# Altium schematic sheet 07 Audio.SchDoc
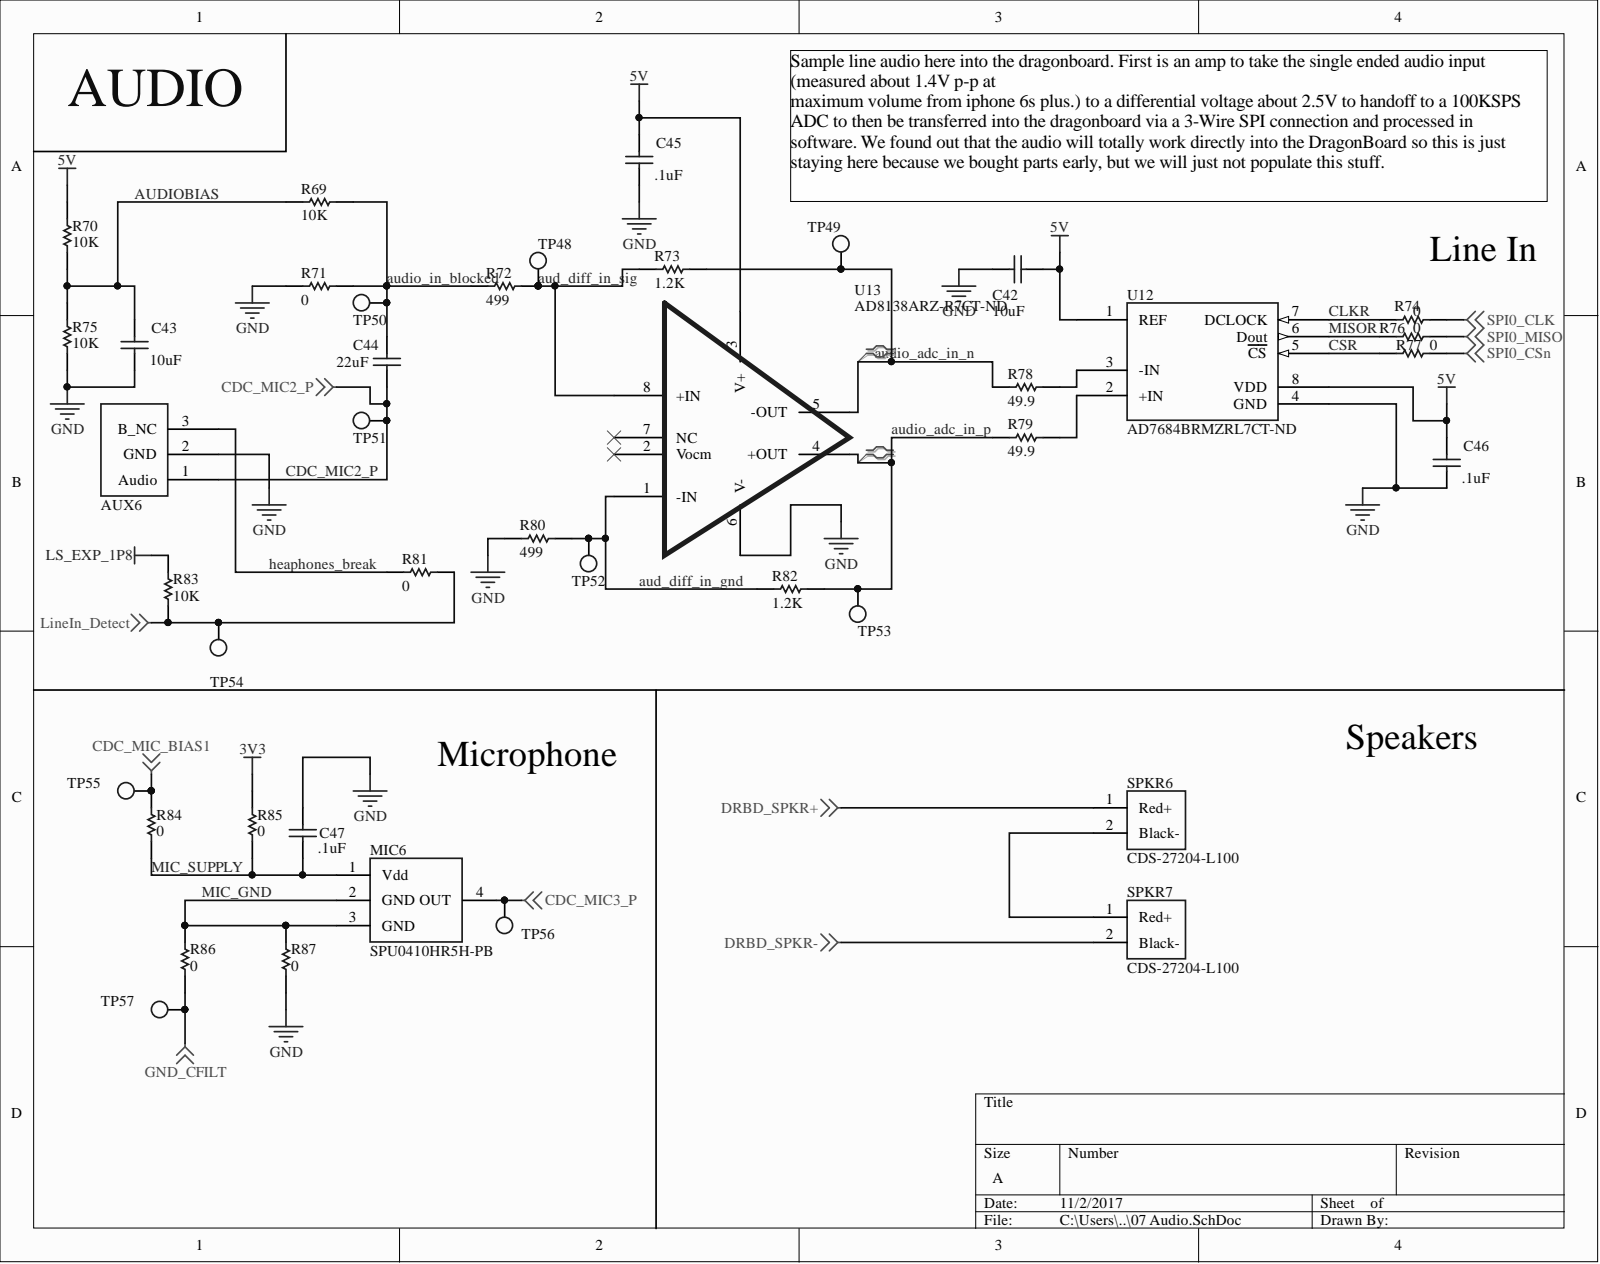
[diagram p0: 07 Audio.SchDoc]

## Parts
AUX6  CP-3523MJCT-ND  [Audio_Conn_Mono]  (value not drawn)
C42  value 10uF  Capacitance: 10uF  [CAPC2012X135N]  (hidden comment: CAP_0805_10u_16V)
C43  value 10uF  Capacitance: 10uF  [CAPC2012X135N]  (hidden comment: CAP_0805_10u_16V)
C44  value 22uF  Capacitance: 22uF  [CAPC2012X135N]  (hidden comment: CAP_0805_22u_25V_BLOCK)
C45  value .1uF  [CAPC2012X135N]  (hidden comment: CAP_0805_.1u_250V)
C46  value .1uF  [CAPC2012X135N]  (hidden comment: CAP_0805_.1u_250V)
C47  value .1uF  [CAPC2012X135N]  (hidden comment: CAP_0805_.1u_250V)
MIC6  SPU0410HR5H-PB  [MIC_FP]
R69  Resistance: 10K  [RES0805]  (hidden comment: RES_0805_10K)
R70  Resistance: 10K  [RES0805]  (hidden comment: RES_0805_10K)
R71  RES_0805_JMP  [RES0805]  (value not drawn)
R72  RES_0805_499  [RES0805]  (value not drawn)
R73  Resistance: 1.2K  [RES0805]  (hidden comment: RES_0805_1.2K)
R74  RES_0805_JMP  [RES0805]  (value not drawn)
R75  Resistance: 10K  [RES0805]  (hidden comment: RES_0805_10K)
R76  RES_0805_JMP  [RES0805]  (value not drawn)
R77  RES_0805_JMP  [RES0805]  (value not drawn)
R78  Resistance: 49.9  [RES0805]  (hidden comment: RES_0805_49.9)
R79  Resistance: 49.9  [RES0805]  (hidden comment: RES_0805_49.9)
R80  RES_0805_499  [RES0805]  (value not drawn)
R81  RES_0805_JMP  [RES0805]  (value not drawn)
R82  Resistance: 1.2K  [RES0805]  (hidden comment: RES_0805_1.2K)
R83  Resistance: 10K  [RES0805]  (hidden comment: RES_0805_10K)
R84  RES_0805_JMP  [RES0805]  (value not drawn)
R85  RES_0805_JMP  [RES0805]  (value not drawn)
R86  RES_0805_JMP  [RES0805]  (value not drawn)
R87  RES_0805_JMP  [RES0805]  (value not drawn)
SPKR6  CDS-27204-L100  [PHOENIX_2POS_1935161]
SPKR7  CDS-27204-L100  [PHOENIX_2POS_1935161]
TP48  TP  [TestPoint_0805]
TP49  TP  [TestPoint_0805]
TP50  TP  [TestPoint_0805]
TP51  TP  [TestPoint_0805]
TP52  TP  [TestPoint_0805]
TP53  TP  [TestPoint_0805]
TP54  TP  [TestPoint_0805]
TP55  TP  [TestPoint_0805]
TP56  TP  [TestPoint_0805]
TP57  TP  [TestPoint_0805]
U12  AD7684BRMZRL7CT-ND  [MSOP8]
U13  AD8138ARZ-R7CT-ND  [SOIC127P600X175-8N]

## Nets
3V3: R85.2
5V: C42.1 C45.2 C46.2 R70.2 U12.1(REF) U12.8(VDD) U13.3(V+)
CDC_MIC3_P: MIC6.4(OUT) TP56.1
CDC_MIC_BIAS1: R84.2 TP55.1
DRBD_SPKR+: SPKR6.1
DRBD_SPKR-: SPKR7.2
GND: AUX6.2 C42.2 C43.1 C45.1 C46.1 C47.2 R71.1 R75.1 R80.1 R87.1 U12.4(GND) U13.6(V-)
GND_CFILT: R86.1 TP57.1
LineIn_Detect: R81.2 R83.1 TP54.1
LS_EXP_1P8: R83.2
SPI0_CLK: R74.2
SPI0_CSn: R77.2
SPI0_MISO: R76.2
aud_diff_in_gnd: R80.2 R82.1 TP52.1 U13.1(-IN)
aud_diff_in_sig: R72.2 R73.1 TP48.1 U13.8(+IN)
audio_adc_in_n: R73.2 R78.1 TP49.1 U13.5(-OUT)
audio_adc_in_p: R79.1 R82.2 TP53.1 U13.4(+OUT)
audio_in_blocked: C44.2 R69.2 R71.2 R72.1 TP50.1
AUDIOBIAS: C43.2 R69.1 R70.1 R75.2
CDC_MIC2_P: AUX6.1 C44.1 TP51.1
CLKR: R74.1 U12.7(DCLOCK)
CSR: R77.1 U12.5(CS)
heaphones_break: AUX6.3 R81.1
MIC_GND: MIC6.2(GND) MIC6.3(GND) R86.2 R87.2
MIC_SUPPLY: C47.1 MIC6.1(Vdd) R84.1 R85.1
MISOR: R76.1 U12.6(Dout)
NetR78_2: R78.2 U12.3(-IN)
NetR79_2: R79.2 U12.2(+IN)
NetSPKR6_2: SPKR6.2 SPKR7.1

Not connected: U13 2(Vocm) 7(NC)

## Library data of the parts
AUX6 = CP-3523MJCT-ND: 3.50mm (0.141", 1/8", Mini Plug) - Headphone Phone Jack Mono Connector Solder; Manufacturer: CUI; Manufacturer Part Number: MJ-3523-SMT-TR; Current Rating: 1A; Gender: Female; Shielding: Unshielded; Case/Package: SMD/SMT; Component Kind: Standard; Contact Plating: Silver; Lead Free: Lead Free; Packaging: Cut Tape; Pricing 1: 1=1.02, 10=0.9, 25=0.8476, 50=0.8122, 100=0.7768, 250=0.7062 (USD); Stock 1: 44959; Supplier 1: Digi-Key; Supplier Part Number 1: CP-3523MJCT-ND; Termination: Solder; Library Name: schlib_truman.SchLib
C42 C43 = CAP_0805_10u_16V: Cap Ceramic 10uF 16V X5R 10% SMD 0805 85C T/R; Manufacturer: Johanson Dielectrics; Manufacturer Part Number: 160R15X106KV4E; Case/Package: 0805; Case Code (Imperial): 0805; Case Code (Metric): 2012; Component Kind: Standard; ComponentLink1Description: https://datasheet.ciiva.com/26948/getdatasheetpartid-132904-26948555.pdf; ComponentLink1URL: https://datasheet.ciiva.com/26948/getdatasheetpartid-132904-26948555.pdf; Depth: 1.27mm; Dielectric: X5R; Height: 1.27mm; Length: 2.03mm; Max Operating Temperature: 85°C; Min Operating Temperature: -55°C; Mount: Surface Mount; Number of Pins: 2; Package Quantity: 2000; Packaging: Tape and Reel; Pricing 1: 1=0.55, 10=0.387, 100=0.2552, 500=0.189, 1000=0.1607 (USD); Radiation Hardening: No; REACH SVHC: No SVHC; Stock 1: 36636; Supplier 1: Digi-Key; Supplier Part Number 1: 709-1229-1-ND; Termination: SMD/SMT; Thickness: 0.06inch; Tolerance: 10%; Voltage Rating: 16V; Voltage Rating (DC): 16V; Weight: 0.000194oz; Width: 1.27mm; Library Name: schlib_truman.SchLib
C44 = CAP_0805_22u_25V_BLOCK: 22µF ±20% 25V X5R Ceramic Capacitor -55°C ~ 85°C Surface Mount, MLCC 0805 (2012 Metric) 0.079" L x 0.049" W (2.00mm x 1.25mm); Manufacturer: Samsung; Manufacturer Part Number: CL21A226MAQNNNE; Case/Package: 0805; Case Code (Imperial): 0805; Case Code (Metric): 2012; Component Kind: Standard; ComponentLink1Description: https://datasheet.ciiva.com/25724/cl21a226maqnnne-25724468.pdf; ComponentLink1URL: https://datasheet.ciiva.com/25724/cl21a226maqnnne-25724468.pdf; Contact Plating: Nickel,Tin; Depth: 1.25mm; Dielectric: X5R; Length: 2mm; Max Operating Temperature: 85°C; Min Operating Temperature: -55°C; Mount: Surface Mount; Package Quantity: 1; Packaging: Tape and Reel; Pricing 1: 1=0.92, 10=0.678, 100=0.465, 500=0.3681, 1000=0.31 (USD); Stock 1: 536403; Supplier 1: Digi-Key; Supplier Part Number 1: 1276-2908-1-ND; Termination: Wraparound; Thickness: 0.055inch; Tolerance: 20%; Voltage Rating: 25V; Width: 0.049inch; Library Name: schlib_truman.SchLib
C45-C47 = CAP_0805_.1u_250V: Cap Ceramic 0.1uF 250V X7T 10% SMD 0805 125C Plastic T/R; Manufacturer: TDK; Manufacturer Part Number: C2012X7T2E104K125AE; Capacitance: 100nF; Case/Package: 0805; Case Code (Imperial): 0805; Case Code (Metric): 2012; Component Kind: Standard; ComponentLink1Description: https://datasheet.ciiva.com/24219/c2012x7t2e104k125ae-265154-24219519.pdf; ComponentLink1URL: https://datasheet.ciiva.com/24219/c2012x7t2e104k125ae-265154-24219519.pdf; Composition: Ceramic; Depth: 1.25mm; Dielectric: X7T; Height: 1.25mm; Length: 2mm; Max Operating Temperature: 125°C; Min Operating Temperature: -55°C; Package Quantity: 1; Packaging: Tape and Reel; Pricing 1: 1=0.37, 10=0.25, 100=0.1419, 500=0.1002, 1000=0.0877 (USD); REACH SVHC: No SVHC; Stock 1: 30376; Supplier 1: Digi-Key; Supplier Part Number 1: 445-8883-1-ND; Termination: SMD/SMT; Thickness: 0.049inch; Tolerance: 10%; Voltage Rating: 250V; Voltage Rating (DC): 250V; Weight: 0.000194oz; Width: 0.05inch; Library Name: schlib_truman.SchLib
MIC6 = SPU0410HR5H-PB: MIC MEMS ANALOG OMNI -42DB; Manufacturer: Knowles; Direction: Omnidirectional; Impedance: 300R; Operating Supply Current: 250uA; Shape: Rectangular; Signal to Noise Ratio (SNR): 59dB; Voltage Rating: 3.6V; Component Kind: Standard; ComponentLink1Description: https://datasheet.ciiva.com/5300/spu0410hr5h-58393-5300133.pdf; ComponentLink1URL: https://datasheet.ciiva.com/5300/spu0410hr5h-58393-5300133.pdf; ComponentLink2Description: https://datasheet.ciiva.com/1/spu0410hr5h-pb-1958.pdf; ComponentLink2URL: https://datasheet.ciiva.com/1/spu0410hr5h-pb-1958.pdf; ComponentLink3Description: https://datasheet.ciiva.com/1/spu0410hr5h-pb-1959.pdf; ComponentLink3URL: https://datasheet.ciiva.com/1/spu0410hr5h-pb-1959.pdf; Depth: 1.2mm; Height: 1.2mm; Length: 3.76mm; Manufacturer Part Number: SPU0410HR5H-PB; Package Quantity: 1200; Packaging: Tape and Reel; Pricing 1: 1=0.94, 10=0.806, 25=0.6476, 50=0.5756, 100=0.5036, 250=0.4892, 500=0.4748 (USD); Stock 1: 176050; Supplier 1: Digi-Key; Supplier Part Number 1: 423-1138-1-ND; Termination: SMD/SMT; Width: 2.95mm; Library Name: schlib_truman.SchLib
R69 R70 R75 R83 = RES_0805_10K: RES, THICK FILM, 10K, 5%, 0.125W, 0805; Manufacturer: Yageo; Manufacturer Part Number: RC0805JR-0710KL; Power Rating: 125mW; Temperature Coefficient: 100ppm/°C; Voltage Rating: 150V; Case/Package: 0805; Case Code (Imperial): 0805; Case Code (Metric): 2012; Component Kind: Standard; ComponentLink1Description: https://datasheet.ciiva.com/7983/444164-7983765.pdf; ComponentLink1URL: https://datasheet.ciiva.com/7983/444164-7983765.pdf; ComponentLink2Description: https://datasheet.ciiva.com/7941/444164-7941870.pdf; ComponentLink2URL: https://datasheet.ciiva.com/7941/444164-7941870.pdf; ComponentLink3Description: https://datasheet.ciiva.com/7941/444164-7941871.pdf; ComponentLink3URL: https://datasheet.ciiva.com/7941/444164-7941871.pdf; Composition: Thick Film; Contact Plating: Tin,Matte; Depth: 1.25mm; Height: 0.5mm; Lead Free: Lead Free; Length: 2mm; Max Operating Temperature: 155°C; Military Standard: Not; Min Operating Temperature: -55°C; Packaging: Tape and Reel; Pricing 1: 1=0.1, 10=0.019, 100=0.0076, 1000=0.0034, 2500=0.003 (USD); Radiation Hardening: No; REACH SVHC: Unknown; Stock 1: 1285290; Supplier 1: Digi-Key; Supplier Part Number 1: 311-10KARCT-ND; Termination: SMD/SMT; Tolerance: 5%; Width: 1.25mm; Library Name: schlib_truman.SchLib
R71 R74 R76 R77 R81 R84-R87 = RES_0805_JMP: RES, THICK FILM, 0R, 0.125W, 0805; Manufacturer: Yageo; Manufacturer Part Number: RC0805JR-070RL; Power Rating: 125mW; Resistance: 0mR; Temperature Coefficient: 200ppm/°C; Tolerance: 5%; Voltage Rating: 150V; : 0; Case/Package: 0805; Case Code (Imperial): 0805; Case Code (Metric): 2012; Component Kind: Standard; ComponentLink1Description: https://datasheet.ciiva.com/7941/1738694-7941861.pdf; ComponentLink1URL: https://datasheet.ciiva.com/7941/1738694-7941861.pdf; ComponentLink2Description: https://datasheet.ciiva.com/7941/1738694-7941862.pdf; ComponentLink2URL: https://datasheet.ciiva.com/7941/1738694-7941862.pdf; ComponentLink3Description: https://datasheet.ciiva.com/11819/0900766b8106beab-11819430.pdf; ComponentLink3URL: https://datasheet.ciiva.com/11819/0900766b8106beab-11819430.pdf; ComponentLink4Description: https://datasheet.ciiva.com/7941/1738694-7941863.pdf; ComponentLink4URL: https://datasheet.ciiva.com/7941/1738694-7941863.pdf; ComponentLink5Description: https://datasheet.ciiva.com/15190/getdatasheetpartid-330685-15190028.pdf; ComponentLink5URL: https://datasheet.ciiva.com/15190/getdatasheetpartid-330685-15190028.pdf; Composition: Thick Film; Contact Plating: Tin,Matte; Depth: 1.25mm; Height: 0.5mm; Lead Free: Lead Free; Length: 2mm; Max Operating Temperature: 155°C; Military Standard: Not; Min Operating Temperature: -55°C; Number of Pins: 2; Packaging: Tape and Reel; Pricing 1: 1=0.1, 10=0.018, 25=0.0128, 50=0.0096, 100=0.0071, 250=0.0054, 500=0.0044, 1000=0.0032 (USD); Radiation Hardening: No; REACH SVHC: Unknown; Stock 1: 6469744; Supplier 1: Digi-Key; Supplier Part Number 1: 311-0.0ARCT-ND; Termination: SMD/SMT; Voltage Rating (DC): 150V; Width: 1.25mm; Library Name: schlib_truman.SchLib
R72 R80 = RES_0805_499: Res Thick Film 0805 499 Ohm 1% 0.125W(1/8W) ±100ppm/C Molded SMD Automotive T/R; Manufacturer: Stackpole Electronics; Manufacturer Part Number: RMCF0805FT499R; Max Power Dissipation: 125mW; Resistance: 499; Temperature Coefficient: 100ppm/°C; Case/Package: 0805; Case Code (Imperial): 0805; Case Code (Metric): 2012; Component Kind: Standard; ComponentLink1Description: https://www.verical.com/server-webapp/html/getDatasheet?partID=363156; ComponentLink1URL: https://www.verical.com/server-webapp/html/getDatasheet?partID=363156; ComponentLink2Description: http://components.arrow.com/part/dataview/44988387S7171819N1174; ComponentLink2URL: http://components.arrow.com/part/dataview/44988387S7171819N1174; ComponentLink3Description: https://www.seielect.com/catalog/SEI-RMCF_RMCP.pdf; ComponentLink3URL: https://www.seielect.com/catalog/SEI-RMCF_RMCP.pdf; ComponentLink4Description: http://www.seielect.com/Catalog/SEI-RMC.pdf; ComponentLink4URL: http://www.seielect.com/Catalog/SEI-RMC.pdf; Composition: Thick Film; Contact Plating: Tin,Matte; Depth: 1.25mm; Height: 0.026inch; Lead Free: Lead Free; Length: 2mm; Max Operating Temperature: 155°C; Military Standard: Not; Min Operating Temperature: -55°C; Mount: Surface Mount; Number of Terminations: 2; Packaging: Tape and Reel; Power Rating: 125mW; Pricing 1: 1=0.1, 10=0.023, 100=0.0095, 1000=0.0043, 2500=0.0037 (USD); Radiation Hardening: No; Stock 1: 258760; Supplier 1: Digi-Key; Supplier Part Number 1: RMCF0805FT499RCT-ND; Tolerance: 1%; Width: 0.049inch; Library Name: schlib_truman.SchLib
R73 R82 = RES_0805_1.2K: Res Thick Film 0805 1.2K Ohm 5% 0.125W(1/8W) ±200ppm/C Molded SMD Automotive T/R; Manufacturer: Stackpole Electronics; Manufacturer Part Number: RMCF0805JT1K20; Max Power Dissipation: 125mW; Temperature Coefficient: 200ppm/°C; Case/Package: 0805; Case Code (Imperial): 0805; Case Code (Metric): 2012; Component Kind: Standard; ComponentLink1Description: https://www.verical.com/server-webapp/html/getDatasheet?partID=408272; ComponentLink1URL: https://www.verical.com/server-webapp/html/getDatasheet?partID=408272; ComponentLink2Description: http://components.arrow.com/part/dataview/50565311S7077410N1174; ComponentLink2URL: http://components.arrow.com/part/dataview/50565311S7077410N1174; ComponentLink3Description: https://www.seielect.com/catalog/SEI-RMCF_RMCP.pdf; ComponentLink3URL: https://www.seielect.com/catalog/SEI-RMCF_RMCP.pdf; Composition: Thick Film; Contact Plating: Tin,Matte; Depth: 1.25mm; Height: 0.026inch; Lead Free: Lead Free; Length: 0.079inch; Max Operating Temperature: 155°C; Military Standard: Not; Min Operating Temperature: -55°C; Mount: Surface Mount; Number of Terminations: 2; Packaging: Tape and Reel; Pricing 1: 1=0.1, 10=0.017, 100=0.0067, 1000=0.003, 2500=0.0026 (USD); Radiation Hardening: No; Stock 1: 133190; Supplier 1: Digi-Key; Supplier Part Number 1: RMCF0805JT1K20CT-ND; Tolerance: 5%; Width: 0.049inch; Library Name: schlib_truman.SchLib
R78 R79 = RES_0805_49.9: RES SMD 49.9 OHM 1% 1/8W 0805; Manufacturer: Yageo; Manufacturer Part Number: RC0805FR-0749R9L; Max Power Dissipation: 125mW; Temperature Coefficient: 100ppm/°C; Voltage Rating: 150V; Case/Package: 0805; Case Code (Imperial): 0805; Case Code (Metric): 2012; Component Kind: Standard; ComponentLink1Description: https://datasheet.ciiva.com/11808/0900766b8106beab-11808260.pdf; ComponentLink1URL: https://datasheet.ciiva.com/11808/0900766b8106beab-11808260.pdf; ComponentLink2Description: https://datasheet.ciiva.com/12667/rc0805-12667333.pdf; ComponentLink2URL: https://datasheet.ciiva.com/12667/rc0805-12667333.pdf; ComponentLink3Description: https://datasheet.ciiva.com/17041/rc0805-17041420.pdf; ComponentLink3URL: https://datasheet.ciiva.com/17041/rc0805-17041420.pdf; Composition: Thick Film; Depth: 1.25mm; Height: 0.024inch; Lead Free: Lead Free; Length: 2mm; Max Operating Temperature: 155°C; Military Standard: Not; Min Operating Temperature: -55°C; Mount: Surface Mount; Number of Terminations: 2; Package Quantity: 1; Packaging: Tape and Reel; Power Rating: 125mW; Pricing 1: 1=0.1, 10=0.022, 100=0.0088, 1000=0.004, 2500=0.0034 (USD); Stock 1: 531225; Supplier 1: Digi-Key; Supplier Part Number 1: 311-49.9CRCT-ND; Termination: SMD/SMT; Tolerance: 1%; Voltage Rating (DC): 150V; Width: 0.049inch; Library Name: schlib_truman.SchLib
SPKR6 SPKR7 = CDS-27204-L100: 4 Ohm Magnetic Speaker 2W 20Hz ~ 20kHz Top Rectangular 93dB; Manufacturer: CUI; Approvals: UL; Connector Type: Screw; Current: 18A; Current Rating: 16A; Impedance: 4R; Max Power Dissipation: 2.5W; Max Supply Voltage: 250V; Number of Circuits: 2; Number of Levels: 1; Pitch: 5mm; Voltage: 300V; Voltage Rating (DC): 320V; Wire/Cable Gauge: 14AWG; Wire Gauge (Max): 26AWG; Wire Gauge (Min): 12AWG; Colour: Green; Component Kind: Standard; ComponentLink1Description: https://datasheet.ciiva.com/824/combiconcompact5-0mmseries-824222.pdf; ComponentLink1URL: https://datasheet.ciiva.com/824/combiconcompact5-0mmseries-824222.pdf; ComponentLink2Description: https://datasheet.ciiva.com/15169/getdatasheetpartid-177903-15169663.pdf; ComponentLink2URL: https://datasheet.ciiva.com/15169/getdatasheetpartid-177903-15169663.pdf; ComponentLink3Description: https://datasheet.ciiva.com/16047/1935161-16047247.pdf; ComponentLink3URL: https://datasheet.ciiva.com/16047/1935161-16047247.pdf; Depth: 9mm; Flammability Rating: UL94 V-0; Height: 6.1mm; Housing Colour: Green; Housing Material: Polyamide; Insulation Material: Nylon; Lead Free: Lead Free; Length: 27mm; Manufacturer Part Number: CDS-27204-L100; Material: Nylon; Max Current Rating: 16A; Mount: Through Hole; Number of Contacts: 2; Number of Poles: 2; Number of Positions: 2; Number of Positions Per Level: 2; Number of Rows: 1; Orientation: Straight; Package Quantity: 250; Packaging: Bulk; Power Rating: 2W; Pricing 1: 1=3.55, 10=3.179, 25=2.8052, 50=2.5246, 100=2.4871, 250=2.3842, 500=2.2908, 1000=2.244 (USD); Pricing 2: 1=0.38, 10=0.368, 50=0.352, 100=0.3424, 250=0.32, 500=0.2944, 1000=0.272 (USD); Radiation Hardening: No; Shape: Rectangular; Stock 1: 445; Stock 2: 16172; Supplier 1: Digi-Key; Supplier 2: Digi-Key; Supplier Part Number 1: 102-3547-ND; Supplier Part Number 2: 277-1667-ND; Termination: Wire Leads; Voltage Rating: 250V; Weight: 0.067021oz; Width: 20mm; Library Name: schlib_truman.SchLib
TP48-TP57 = TP: Testpoint_0805; Manufacturer: TE Connectivity; Manufacturer Part Number: 1625854-2; Resistance: 0mR; Case/Package: 0805; Colour: Natural; Component Kind: Standard; ComponentLink1Description: https://datasheet.ciiva.com/6439/eng-ds-1773266-a-6439169.pdf; ComponentLink1URL: https://datasheet.ciiva.com/6439/eng-ds-1773266-a-6439169.pdf; ComponentLink2Description: https://datasheet.ciiva.com/30238/1773266-a-30238966.pdf; ComponentLink2URL: https://datasheet.ciiva.com/30238/1773266-a-30238966.pdf; ComponentLink3Description: https://datasheet.ciiva.com/8914/eng-ds-1773266-a-8914649.pdf; ComponentLink3URL: https://datasheet.ciiva.com/8914/eng-ds-1773266-a-8914649.pdf; Depth: 1.2mm; Lead Free: Lead Free; Length: 2mm; Max Operating Temperature: 125°C; Military Standard: Not; Min Operating Temperature: -55°C; Mount: Surface Mount; Package Quantity: 2000; Packaging: Tape and Reel; Pricing 1: 1=0.21, 10=0.2, 25=0.1708, 50=0.1496, 100=0.1389, 250=0.1229, 500=0.1175, 1000=0.0962 (USD); Radiation Hardening: No; Stock 1: 27299; Supplier 1: Digi-Key; Supplier Part Number 1: A106144CT-ND; Library Name: schlib_truman.SchLib
U12 = AD7684BRMZRL7CT-ND: IC ADC 16BIT 100KSPS DIFF 8MSOP; Manufacturer: Analog Devices; Full Scale Error: 15LSB; Input Capacitance: 30pF; Integral Nonlinearity (INL): 3LSB; Interface: SPI; Max Input Voltage: 5.5V; Max Power Dissipation: 6mW; Min Input Voltage: 500mV; Min Supply Voltage: 2.7V; Number of A/D Converters: 1; Number of Bits: 16; Polarity: Bipolar; Power Consumption: 4mW; Resolution: 16b; Sampling Rate: 100KS/s; Signal to Noise Ratio (SNR): 91dB; Supply Type: Single; Supply Voltage: 5V; Case/Package: MSOP; Component Kind: Standard; ComponentLink1Description: https://datasheet.ciiva.com/16322/ad7684-278579-16322642.pdf; ComponentLink1URL: https://datasheet.ciiva.com/16322/ad7684-278579-16322642.pdf; ComponentLink2Description: https://datasheet.ciiva.com/8195/ad7684-8195814.pdf; ComponentLink2URL: https://datasheet.ciiva.com/8195/ad7684-8195814.pdf; ComponentLink3Description: https://datasheet.ciiva.com/1287/ad7684-1287305.pdf; ComponentLink3URL: https://datasheet.ciiva.com/1287/ad7684-1287305.pdf; ComponentLink4Description: https://datasheet.ciiva.com/16612/ad7684-16612158.pdf; ComponentLink4URL: https://datasheet.ciiva.com/16612/ad7684-16612158.pdf; Contact Plating: Gold; Height: 0.85mm; Lead Free: Contains Lead; Length: 3mm; Manufacturer Part Number: AD7684BRMZRL7; Max Operating Temperature: 85°C; Max Supply Voltage: 5.5V; Min Operating Temperature: -40°C; Number of Analog Inputs: 1; Number of Channels: 1; Number of Converters: 1; Number of Elements: 1; Number of Inputs: 1; Number of Pins: 8; Package Quantity: 1000; Packaging: Tape and Reel; Power Dissipation: 6mW; Pricing 1: 1=14.81, 10=13.613, 100=11.4968 (USD); Stock 1: 2500; Supplier 1: Digi-Key; Supplier Part Number 1: AD7684BRMZRL7CT-ND; Width: 3mm; Library Name: schlib_truman.SchLib
U13 = AD8138ARZ-R7CT-ND: SP Amp Differential ADC Driver Single ±5.5V/11V 8-Pin SOIC N T/R; Manufacturer: Analog Devices; -3db Bandwidth: 320MHz; Common Mode Rejection Ratio: 70dB; Input Bias Current: 7uA; Input Offset Voltage (Vos): 1mV; Input Resistance: 6MR; Max Dual Supply Voltage: 5.5V; Max Power Dissipation: 550mW; Max Supply Voltage: 11V; Min Dual Supply Voltage: 1.4V; Min Supply Voltage: 2.7V; Nominal Supply Current: 20mA; Number of Channels: 1; Slew Rate: 1150V/µs; Voltage Gain: 0.028dB; Bandwidth: 320MHz; Case/Package: SOIC; Component Kind: Standard; ComponentLink1Description: https://datasheet.ciiva.com/2975/ad8138-2975957.pdf; ComponentLink1URL: https://datasheet.ciiva.com/2975/ad8138-2975957.pdf; ComponentLink2Description: https://datasheet.ciiva.com/14848/ad8138-14848182.pdf; ComponentLink2URL: https://datasheet.ciiva.com/14848/ad8138-14848182.pdf; ComponentLink3Description: https://datasheet.ciiva.com/7615/ad8138-7615959.pdf; ComponentLink3URL: https://datasheet.ciiva.com/7615/ad8138-7615959.pdf; ComponentLink4Description: https://datasheet.ciiva.com/14848/ad8138-14848183.pdf; ComponentLink4URL: https://datasheet.ciiva.com/14848/ad8138-14848183.pdf; ComponentLink5Description: https://datasheet.ciiva.com/17371/ad8138-17371687.pdf; ComponentLink5URL: https://datasheet.ciiva.com/17371/ad8138-17371687.pdf; ComponentLink6Description: https://datasheet.ciiva.com/15128/getdatasheetpartid-86354-15128216.pdf; ComponentLink6URL: https://datasheet.ciiva.com/15128/getdatasheetpartid-86354-15128216.pdf; ComponentLink7Description: https://datasheet.ciiva.com/2975/ad8138-2975645.pdf; ComponentLink7URL: https://datasheet.ciiva.com/2975/ad8138-2975645.pdf; ComponentLink8Description: https://datasheet.ciiva.com/15382/ad8138-246651-15382031.pdf; ComponentLink8URL: https://datasheet.ciiva.com/15382/ad8138-246651-15382031.pdf; Gain Bandwidth Product: 320MHz; Lead Free: Contains Lead; Manufacturer Part Number: AD8138ARZ-R7; Max Operating Temperature: 85°C; Min Operating Temperature: -40°C; Mount: Surface Mount; Number of Circuits: 1; Number of Elements: 1; Number of Pins: 8; Operating Supply Current: 20mA; Package Quantity: 1000; Packaging: Tape and Reel; Power Dissipation: 550mW; Power Supply Rejection Ratio (PSRR): 70dB; Pricing 1: 1=9.26, 10=8.365, 100=6.9255, 500=6.0306 (USD); Radiation Hardening: No; Stock 1: 0; Supplier 1: Digi-Key; Supplier Part Number 1: AD8138ARZ-R7CT-ND; Library Name: schlib_truman.SchLib
